# Altium schematic sheet BufferAmp.SchDoc(BufferAmp)
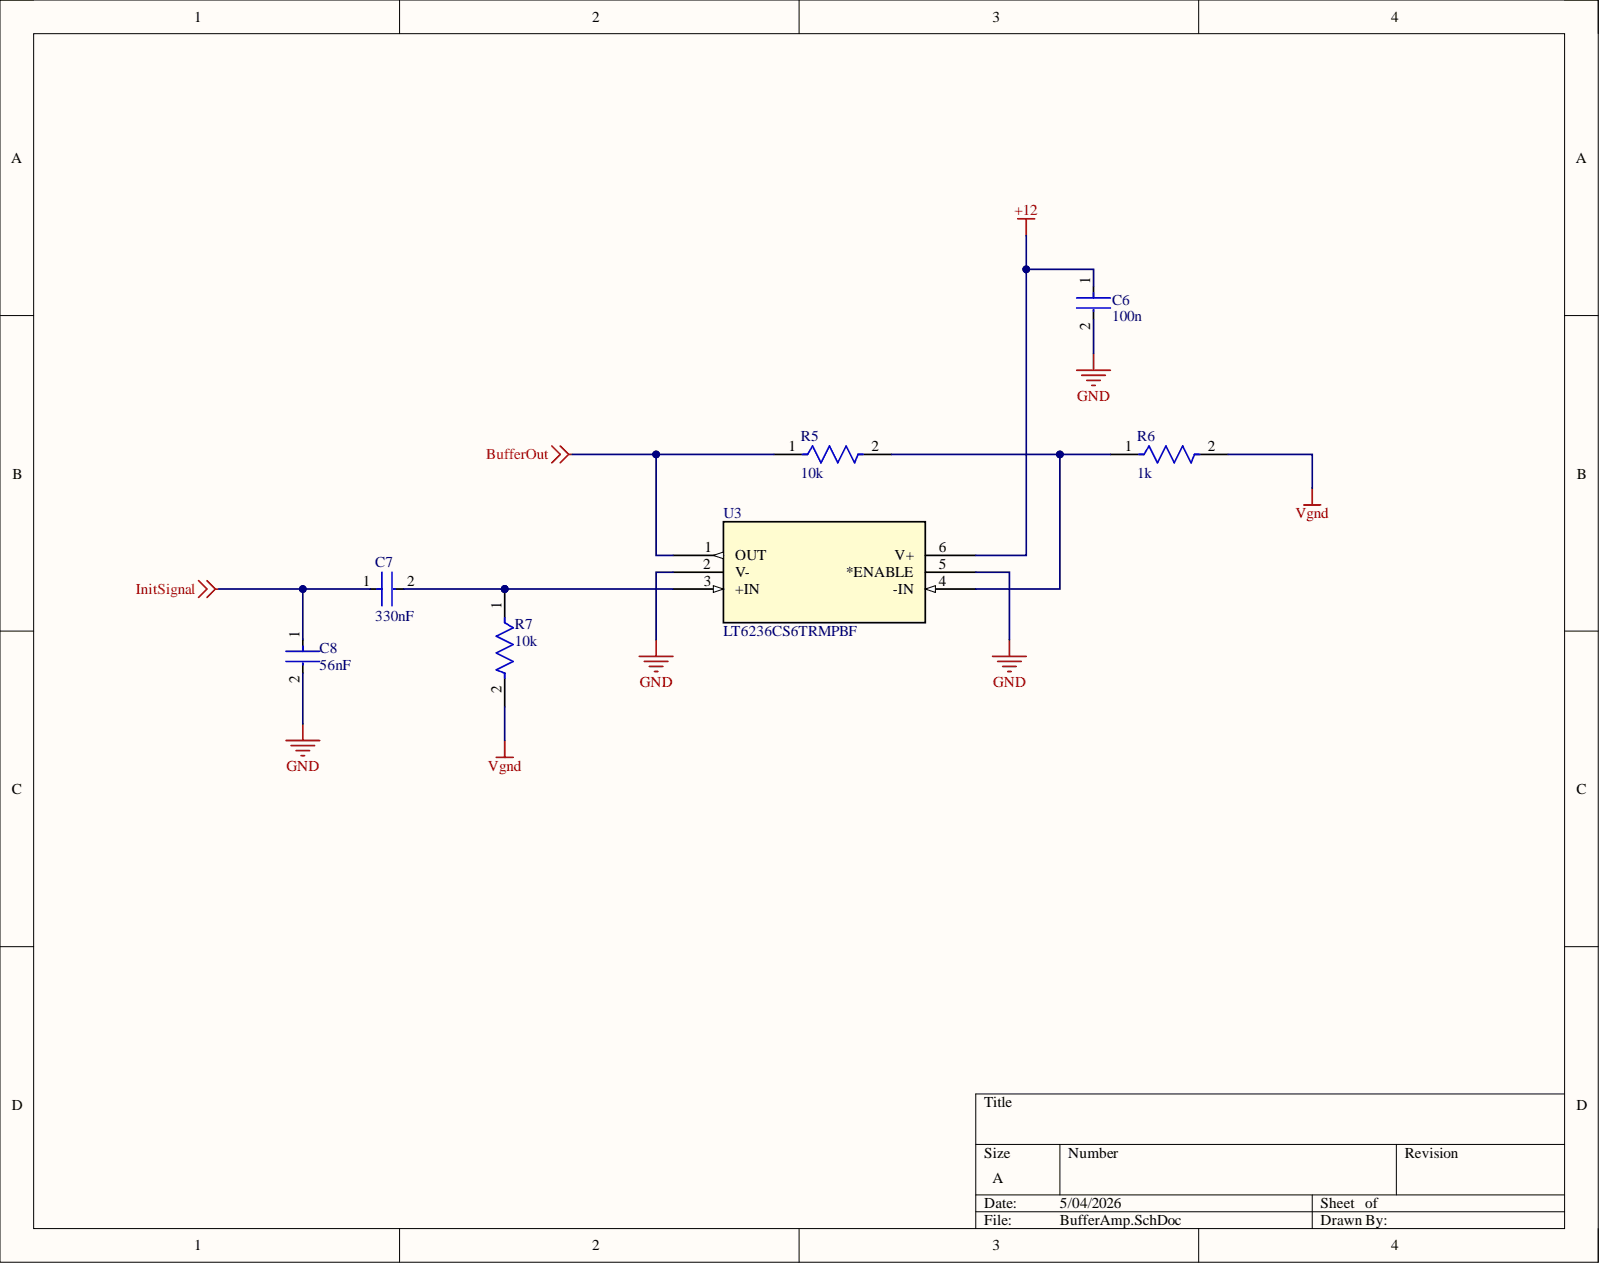
[diagram p0: BufferAmp.SchDoc]

## Parts
C6  100n
C7  330nF
C8  Capacitance: 56nF  (hidden comment: GRM31M5C1H563JA01L)
R5  10k
R6  1k
R7  10k
U3  LT6236CS6TRMPBF

## Nets
+12: C6.1 U3.6(V+)
BufferOut: R5.1 U3.1(OUT)
GND: C6.2 C8.2 U3.2(V-) U3.5(*ENABLE)
InitSignal: C7.1 C8.1
Vgnd: R6.2 R7.2
NetC7_2: C7.2 R7.1 U3.3(+IN)
NetR5_2: R5.2 R6.1 U3.4(-IN)

## Library data of the parts
C6 = CMP-06035-028588-1: Chip Multilayer Ceramic Capacitors for General Purpose, 1206, 0.10uF, C0G, 30ppm/°C, 5%, 50V; Component Kind: Standard; Library Name: Altium Content Vault
C7 = CMP-06035-063390-1: CAP CER 0.33UF 25V C0G/NP0 1206; Component Kind: Standard; Library Name: Altium Content Vault; RoHS Compliant: Yes
C8 = CMP-1037-04037-2: Chip Multilayer Ceramic Capacitors for General Purpose, 1206, 56000pF, C0G, 30ppm/°C, 5%, 50V; Case Code (Imperial): 1206; Case Code (Metric): 3216; Case/Package: 1206; Component Kind: Standard; Depth: 1.6mm; Dielectric: C0G; Height: 1.15mm; Lead Free: Lead Free; Length: 3.2mm; Library Name: Altium Content Vault; Max Operating Temperature: 125°C; Min Operating Temperature: -55°C; Mount: Surface Mount; Package Quantity: 3000; Packaging: Tape and Reel; Radiation Hardening: No; Termination: SMD/SMT; Thickness: 0.049inch; Tolerance: 5%; Voltage Rating: 50V; Voltage Rating (DC): 50V; Width: 0.063inch
R5 R7 = CMP-2001-03215-2: 10kΩ ±0.5% 0.1W 1/10W Chip Resistor 0805 (2012 Metric) Thin Film; Max Power Dissipation: 100mW; Resistance: 10kR; Temperature Coefficient: 25ppm/°C; Voltage Rating: 100V; Case Code (Imperial): 0805; Case Code (Metric): 2012; Case/Package: 0805; Component Kind: Standard; Depth: 1.25mm; Height: 0.4mm; Lead Free: Lead Free; Length: 2mm; Library Name: Altium Content Vault; Max Operating Temperature: 125°C; Min Operating Temperature: -55°C; Number of Terminations: 2; Package Quantity: 1; Packaging: Tape and Reel; Power Rating: 100mW; Resistance Tolerance: 0.5%; Resistor Type: Thin Film; RoHS Compliant: Yes; Series: RR; Size Code: 0805; Technology: THIN FILM; Termination: SMD/SMT; Tolerance: 0.5%; Voltage Rating (DC): 100V; Weight: 0.000194oz; Width: 1.25mm
R6 = CMP-2001-03210-2: 1kΩ ±0.5% 0.1W 1/10W Chip Resistor 0805 (2012 Metric) Thin Film; Max Power Dissipation: 100mW; Resistance: 1kR; Temperature Coefficient: 25ppm/°C; Voltage Rating: 100V; Case Code (Imperial): 0805; Case Code (Metric): 2012; Case/Package: 0805; Component Kind: Standard; Depth: 1.25mm; Height: 0.4mm; Lead Free: Lead Free; Length: 2mm; Library Name: Altium Content Vault; Max Operating Temperature: 125°C; Min Operating Temperature: -55°C; Number of Terminations: 2; Package Quantity: 1; Packaging: Tape and Reel; Power Rating: 100mW; Resistance Tolerance: 0.5%; Resistor Type: Thin Film; RoHS Compliant: Yes; Series: RR; Size Code: 0805; Technology: THIN FILM; Termination: SMD/SMT; Tolerance: 0.5%; Voltage Rating (DC): 100V; Width: 1.25mm
U3 = CMP-014-00002-1: Rail-to-Rail Output 215MHz, 1.1nV/âˆšHz Op Amp/ SAR ADC Driver; Manufacturer_Name: Analog Devices Inc; Manufacturer_Part_Number: LT6236CS6#TRMPBF; Component Kind: Standard; Datasheet: https://www.analog.com/media/en/technical-documentation/data-sheets/623637fb.pdf; Design Item ID: LT6236CS6TRMPBF; RefDes: RefDes; Source: LT6236CS6TRMPBF.SchLib; Type: Type; Copyright: Copyright (C) 2026 Ultra Librarian. All rights reserved.; Library Name: James Brasfield
